# Altium schematic sheet MicroController2.SchDoc
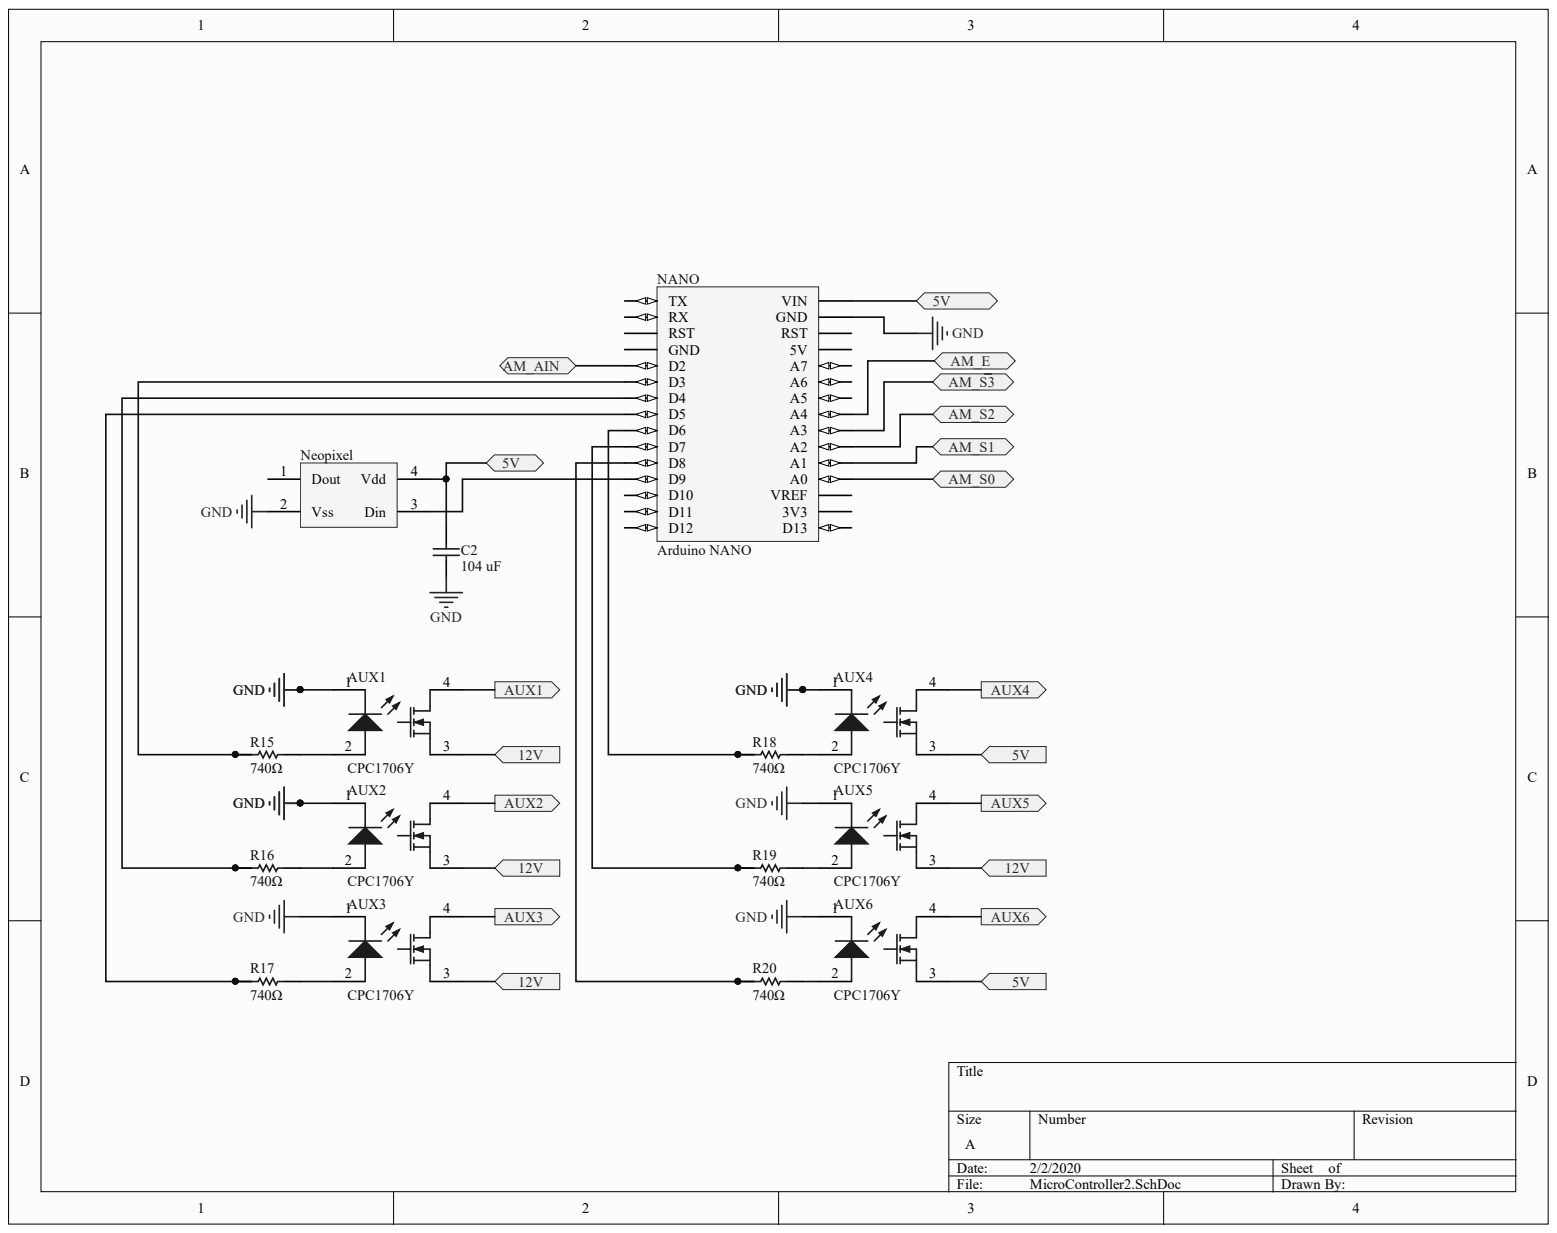
[diagram p0: MicroController2.SchDoc]

## Parts
AUX1  CPC1706Y  [PCB-a84pemv5c7g1cyzs16sn-1]
AUX2  CPC1706Y  [PCB-a84pemv5c7g1cyzs16sn-1]
AUX3  CPC1706Y  [PCB-a84pemv5c7g1cyzs16sn-1]
AUX4  CPC1706Y  [PCB-a84pemv5c7g1cyzs16sn-1]
AUX5  CPC1706Y  [PCB-a84pemv5c7g1cyzs16sn-1]
AUX6  CPC1706Y  [PCB-a84pemv5c7g1cyzs16sn-1]
C2  104 uF  [CAPC3225X94X50ML20T25]
NANO  Arduino NANO  [PCB-vv8a1hzx923o7t0c3sdz-1]
Neopixel  (no value)  [PCB-kt91jo9t2ht63m2p7oj6-1]
R15  740Ω  [RESC3225X60X45NL20T20]
R16  740Ω  [RESC3225X60X45NL20T20]
R17  740Ω  [RESC3225X60X45NL20T20]
R18  740Ω  [RESC3225X60X45NL20T20]
R19  740Ω  [RESC3225X60X45NL20T20]
R20  740Ω  [RESC3225X60X45NL20T20]

## Ports
5V, 12V, AM_AIN, AM_E, AM_S0, AM_S1, AM_S2, AM_S~{3}, AUX1, AUX2, AUX3, AUX4, AUX5, AUX6

## Nets
GND: AUX1.1 AUX2.1 AUX3.1 AUX4.1 AUX5.1 AUX6.1 C2.2 NANO.29(GND) Neopixel.2(Vss)
5V: AUX4.3 AUX6.3 C2.1 NANO.30(VIN) Neopixel.4(Vdd) ; port 5V
12V: AUX1.3 AUX2.3 AUX3.3 AUX5.3 ; port 12V
AM_AIN: NANO.5(D2) ; port AM_AIN
AM_E: NANO.23(A4) ; port AM_E
AM_S0: NANO.19(A0) ; port AM_S0
AM_S1: NANO.20(A1) ; port AM_S1
AM_S2: NANO.21(A2) ; port AM_S2
AM_S~{3}: NANO.22(A3) ; port AM_S~{3}
AUX1: AUX1.4 ; port AUX1
AUX2: AUX2.4 ; port AUX2
AUX3: AUX3.4 ; port AUX3
AUX4: AUX4.4 ; port AUX4
AUX5: AUX5.4 ; port AUX5
AUX6: AUX6.4 ; port AUX6
NetAUX1_2: AUX1.2 R15.2
NetAUX2_2: AUX2.2 R16.2
NetAUX3_2: AUX3.2 R17.2
NetAUX4_2: AUX4.2 R18.2
NetAUX5_2: AUX5.2 R19.2
NetAUX6_2: AUX6.2 R20.2
NetNANO_6: NANO.6(D3) R15.1
NetNANO_7: NANO.7(D4) R16.1
NetNANO_8: NANO.8(D5) R17.1
NetNANO_9: NANO.9(D6) R18.1
NetNANO_10: NANO.10(D7) R19.1
NetNANO_11: NANO.11(D8) R20.1
NetNANO_12: NANO.12(D9) Neopixel.3(Din)

Not connected: NANO 1(TX) 2(RX) 3(RST) 4(GND) 13(D10) 14(D11) 15(D12) 16(D13) 17(3V3) 18(VREF) 24(A5) 25(A6) 26(A7) 27(5V) 28(RST); Neopixel 1(Dout)

## Library data of the parts
AUX1-AUX6 = CMP-a6bb6ee89121797c-2: CPC1706 Series 4 A 60 V SPST Through Hole OptoMOS® DC Power Relay - SIP-4; Lead-Free Status: Lead Free; Component Kind: Standard; Library Name: CommunityVault; Manufacturer Part Number: CPC1706Y; Mounting Style: PCB, Through Hole; OctopartID: a6bb6ee89121797c; Octopart URL: http://octopart.com/cpc1706y-ixys-22614780; Packaging: Tube, Rail; RoHS: Compliant
C2 = CMP-126956-2: CAP CER 0.15UF 50V 10% X7R 1210; Manufacturer Part Number: 12105C154KAT2A; Capacitance: 1.5e-07 F; Dielectric Material: Ceramic; Lead-Free Status: Lead Free; Voltage Rating (DC): 50.0 V; Capacitance Tolerance: ±10%; Case/Package: 1210; Component Kind: Standard; Dielectric Characteristic: X7R; Library Name: CommunityVault; Mounting Style: Surface Mount; Number of Pins: 2; OctopartID: f3ce3ac62c902c09; Octopart Url: https://octopart.com/12105c154kat2a-avx+interconnect+%2F+elco-195128; Packaging: Cut Tape (CT); RoHS: Compliant; Size-Height: 0.00094 m; Size-Length: 0.0032 m; Size-Width: 0.0025 m
NANO = CMP-f1bd39841b322e7a-66: Nano 3.0 Atmel Atmega 328 MCU board; Manufacturer Part Number: A000005; Component Kind: Standard; Library Name: CommunityVault; Octopart URL: https://octopart.com/a000005-arduino-20172777; REACH SVHC Compliance: No SVHC
Neopixel = CMP-531399334a2b8cd4-4: NEOPIXEL MINI 3535 RGB; Component Kind: Standard; Library Name: CommunityVault
R15-R20 = CMP-1860323-2: RES SMD 10 OHM 1% 1/2W 1210; Manufacturer Part Number: CRCW121010R0FKEA; Lead-Free Status: Lead Free; Power Rating: 0.5 W; Resistance: 10.0 Ω; Voltage Rating (DC): 200.0 V; Case/Package: 1210; Component Kind: Standard; Library Name: CommunityVault; Mounting Style: Surface Mount; Number of Pins: 2; OctopartID: 62eca9b9d586bd2b; Octopart Url: https://octopart.com/crcw121010r0fkea-vishay-39817740; Packaging: Cut Tape (CT); REACH SVHC Compliance: No SVHC; Resistance Tolerance: ±1%; RoHS: Compliant; Size-Height: 0.00055 m; Size-Length: 0.0032 m; Size-Width: 0.0025 m
